# Altium schematic sheet RGB_LED_Stage_Light.SchDoc
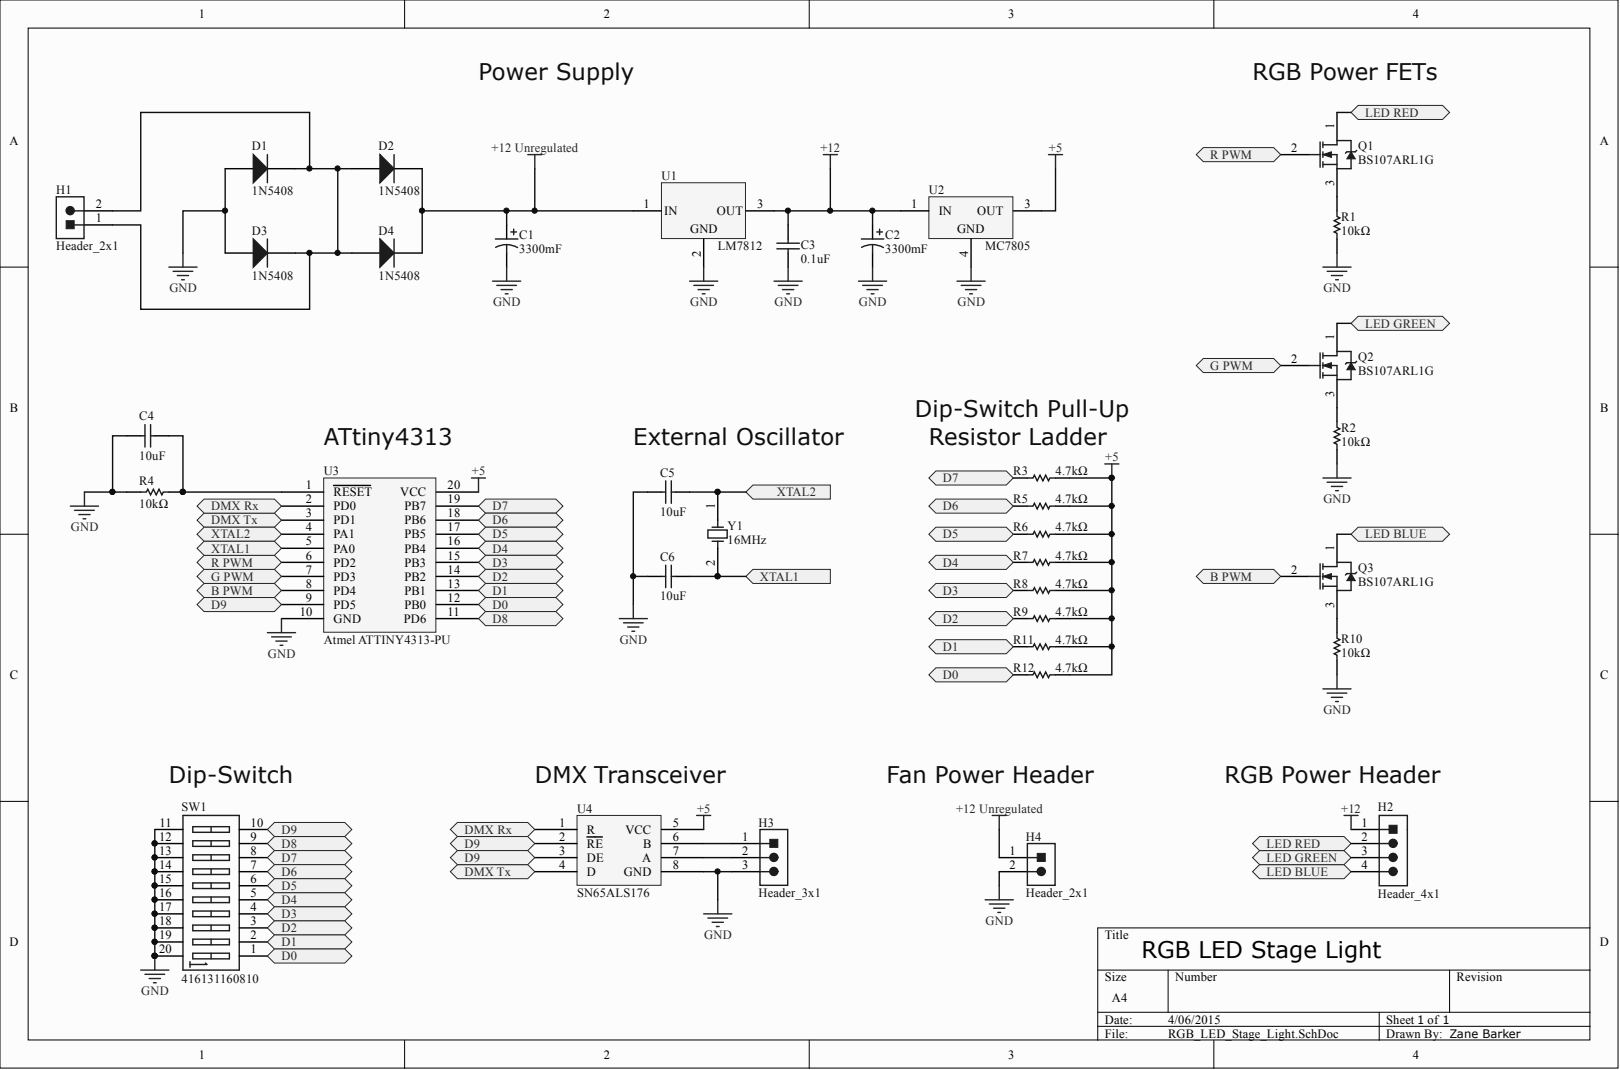
[diagram p0: RGB_LED_Stage_Light.SchDoc]

## Parts
C1  3300mF  [CAPM7343X310X130ML30T30]
C2  3300mF  [CAPM7343X310X130ML30T30]
C3  0.1uF  [PCB-3552395-2]
C4  10uF  [PCB-3552395-2]
C5  10uF  [PCB-3552395-2]
C6  10uF  [PCB-3552395-2]
D1  1N5408  [PCB-89mq2y49z58ewcnvjsi1-1]
D2  1N5408  [PCB-89mq2y49z58ewcnvjsi1-1]
D3  1N5408  [PCB-89mq2y49z58ewcnvjsi1-1]
D4  1N5408  [PCB-89mq2y49z58ewcnvjsi1-1]
H1  Header_2x1
H2  Header_4x1  [JST-S4B-XH-A(LF)(SN)]
H3  Header_3x1  [JST-B3P-VH(LF)(SN)]
H4  Header_2x1
Q1  BS107ARL1G  [ONSC-TO-92-3-29-11_AM_BL]
Q2  BS107ARL1G  [ONSC-TO-92-3-29-11_AM_BL]
Q3  BS107ARL1G  [ONSC-TO-92-3-29-11_AM_BL]
R1  10kΩ  [RESC1005X40X25NL10T10]
R2  10kΩ  [RESC1005X40X25NL10T10]
R3  4.7kΩ  [RESC1005X40X25NL10T10]
R4  10kΩ  [RESC1005X40X25NL10T10]
R5  4.7kΩ  [RESC1005X40X25NL10T10]
R6  4.7kΩ  [RESC1005X40X25NL10T10]
R7  4.7kΩ  [RESC1005X40X25NL10T10]
R8  4.7kΩ  [RESC1005X40X25NL10T10]
R9  4.7kΩ  [RESC1005X40X25NL10T10]
R10  10kΩ  [RESC1005X40X25NL10T10]
R11  4.7kΩ  [RESC1005X40X25NL10T10]
R12  4.7kΩ  [RESC1005X40X25NL10T10]
SW1  416131160810  [416131160810]
U1  LM7812
U2  MC7805  [ONSC-MC7805ACD2TG]
U3  Atmel ATTINY4313-PU  Manufacturer Part Number: ATTINY4313-PU
U4  SN65ALS176
Y1  16MHz  [FOX-277LF-16000000_V]

## Ports
B PWM, D0, D1, D2, D3, D4, D5, D6, D7, D8, D9, DMX RX, DMX TX, G PWM, LED BLUE, LED GREEN, LED RED, R PWM, XTAL1, XTAL2

## Nets
+5: R3.2 R5.2 R6.2 R7.2 R8.2 R9.2 R11.2 R12.2 U2.3 U3.20(VCC) U4.5(VCC)
+12: C2.1 C3.2 H2.1 U1.3 U2.1
+12 UNREGULATED: C1.1 D2.2 D4.2 H4.1 U1.1
GND: C1.2 C2.2 C3.1 C4.2 C5.2 C6.2 D1.1 D3.1 H3.3 H4.2 R1.1 R2.1 R4.1 R10.1 SW1.11 SW1.12 SW1.13 SW1.14 SW1.15 SW1.16 SW1.17 SW1.18 SW1.19 SW1.20 U1.2 U2.4 U3.10(GND) U4.8(GND)
B PWM: Q3.2 U3.8(PD4) ; port B PWM
D0: R12.1 SW1.1 U3.12(PB0) ; port D0
D1: R11.1 SW1.2 U3.13(PB1) ; port D1
D2: R9.1 SW1.3 U3.14(PB2) ; port D2
D3: R8.1 SW1.4 U3.15(PB3) ; port D3
D4: R7.1 SW1.5 U3.16(PB4) ; port D4
D5: R6.1 SW1.6 U3.17(PB5) ; port D5
D6: R5.1 SW1.7 U3.18(PB6) ; port D6
D7: R3.1 SW1.8 U3.19(PB7) ; port D7
D8: SW1.9 U3.11(PD6) ; port D8
D9: SW1.10 U3.9(PD5) U4.2(RE) U4.3(DE) ; port D9
G PWM: Q2.2 U3.7(PD3) ; port G PWM
LED BLUE: H2.4 Q3.1 ; port LED BLUE
LED GREEN: H2.3 Q2.1 ; port LED GREEN
LED RED: H2.2 Q1.1 ; port LED RED
R PWM: Q1.2 U3.6(PD2) ; port R PWM
XTAL1: C6.1 U3.5(PA0) Y1.2 ; port XTAL1
XTAL2: C5.1 U3.4(PA1) Y1.1 ; port XTAL2
NetC4_1: C4.1 R4.2 U3.1(RESET)
NetD1_2: D1.2 D2.1 D3.2 D4.1 H1.1 H1.2
NetH3_1: H3.1 U4.6(B)
NetH3_2: H3.2 U4.7(A)
NetQ1_3: Q1.3 R1.2
NetQ2_3: Q2.3 R2.2
NetQ3_3: Q3.3 R10.2
NetU3_2: U3.2(PD0) U4.1(R) ; port DMX RX
NetU3_3: U3.3(PD1) U4.4(D) ; port DMX TX

## Library data of the parts
C1 C2 = CMP-221418-1: CAP TANT 100UF 10V 10% 2917; Manufacturer Part Number: 293D107X9010D2TE3; Capacitance: 100000000 pF; Case Style: Molded; CiivaID: 221418; DCL: 10 uA; DELETED: Compliant; DF: 8%; ESR: 0.6 ohm; Insulation: Not Required; Lead Spacing (nom): Not Required mm; Polarity: Polar; Product Depth (mm): 4.3 mm; Product Height (mm): 2.8 mm; Product Length (mm): 7.3 mm; Rad Hardened: No; Voltage: 10VDC; Case Code: D; Ciiva URL: https://ciiva.com/part/293D107X9010D2TE3-221418; Component Kind: Standard; Construction: SMT Chip; Failure Rate: Not Required; Length W/Weld: Not Required mm; Length W/Weld (max): Not Required mm; Library Name: CommunityVault; Mounting Style: Surface Mount; Operating Temp Range: -55C to 125C; Package / Case: 7343-31; Packaging: Tape and Reel; Product Diameter (mm): Not Required mm; Seal: Not Required; Seated Plane Height: Not Required mm; Tolerance (+ or -): 10%; Wire Form: Not Required
C3-C6 = CMP-3552395-A.1: Multilayer Ceramic Capacitors MLCC - SMD/SMT 0603 0.01uF 16volts X7R 5%; Manufacturer: AVX; Manufacturer Part Number: 0603YC103JAZ2A; Product Depth: 0.81 mm; Product Height: 0.94(Max) mm; Product Length: 1.6 mm; Rad Hard: No; Voltage: 16 Vdc; Capacitance Value: 0.01 uF; Category: Capacitor Ceramic Multilayer; CiivaID: 3552395; Ciiva URL: https://ciiva.com/part/0603YC103JAZ2A-3552395; Component Kind: Standard; Construction: Flat; Dielectric: X7R; Library Name: CommunityVault; Mounting: Surface Mount; Operating Temperature: -55 to 125 °C; Package/Case: 0603; Packaging: Tape & Reel; Tolerance: 5 %
D1-D4 = 1N5408-A.1: Standard Recovery Rectifier, 2-Pin Axial_Lead, Pb-Free, Bulk Box; CustomComponentID: 89mq2y49z58ewcnvjsi1; Component Kind: Standard; Library Name: FavoritesLibrary
H1 H4 = CMP-751870-A.1: TERM BLOCK 2POS SIDE ENT 3.81MM; Manufacturer Part Number: 1776113-2; CiivaID: 751870; Clamp Material - Plating: Copper Alloy - Nickel Plated; Current: 12A; Dynamic Catalog: Buchanan Series; Featured Product: Eurostyle Terminal Block Connectors; Mating Orientation: Horizontal with Board; Number of Levels: 1; Other Names: A97976; Pitch: 0.150" (3.81mm); Positions Per Level: 2; Product Training Modules: Wire-to-Board Eurostyle Terminal Blocks; Voltage: 150V; Wire Gauge: 16-28 AWG; Wire Termination: Screw - Rising Cage Clamp; Category: Connectors, Interconnects; Ciiva URL: https://ciiva.com/part/1776113-2-751870; Color: Black; Component Kind: Standard; Contact Material - Plating: Copper Alloy - Tin Plated; Family: Terminal Blocks - Wire to Board; Housing Material: Polyamide (PA), Nylon; Library Name: CommunityVault; Mounting Type: Through Hole; Operating Temperature: -40°C ~ 110°C; Packaging: Bulk; RoHS Information: 1776113-2 Statement of Compliance; Screw Material - Plating: Copper Alloy - Nickel Plated; Series: Buchanan; Standard Package: 300
H2 = CMP-656563-1: CONN HEADER XH SIDE 4POS 2.5MM; Manufacturer Part Number: S4B-XH-A(LF)(SN); CiivaID: 656563; No of Positions: 4; Style/Orientation: Right Angle Header/Plug; Ciiva URL: https://ciiva.com/part/S4B-XH-A(LF)(SN)-656563; Component Kind: Standard; Library Name: CommunityVault; Pitch: 2.5 mm; Termination: Press-fit; Type: Shrouded Header
H3 = CMP-642977-1: CONN HEADER VH TOP 3POS 3.96MM; Manufacturer Part Number: B3P-VH(LF)(SN); CiivaID: 642977; No of Positions: 3; Style/Orientation: Straight Header/Plug; Ciiva URL: https://ciiva.com/part/B3P-VH(LF)(SN)-642977; Component Kind: Standard; Library Name: CommunityVault; Pitch: 3.96 mm; Termination: Crimp; Type: Locking Header
Q1-Q3 = CMP-3782533-1: Small Signal MOSFET, 250 A, 200 V, N-Channel, 3-Pin TO-92, Pb-Free, Tape and Reel; Channel Mode: Enhancement; Continuous Drain Current: 0.25 A; Drain-Source On-Res: 6.4 ohm; Drain-Source On-Volt: 200 V; Drain Efficiency: Not Required %; Frequency (Max): Not Required MHz; Gate-Source Voltage (Max): ?20 V; Noise Figure: Not Required dB; Number of  Elements: 1; Output Power (Max): Not Required W; Polarity: N; Power Dissipation: 0.35 W; Rad Hardened: No; CiivaID: 3782533; Ciiva URL: https://ciiva.com/part/BS107ARL1G-3782533; Component Kind: Standard; Drain Current (Max): 0.25 A; Library Name: CommunityVault; Mounting: Through Hole; Operating Temperature Classification: Military; Operating Temp Range: -55C to 150C; Package Type: TO-92; Packaging: Tape and Reel; Pin Count: 3; Power Gain: Not Required dB; Type: Small Signal
R1-R12 = CMP-2407988-1: Thick Film Resistors 10K OHM %; Manufacturer Part Number: CR0402-FX-1002GLF; Brand: Bourns; Case Height: 0.35 mm; Case Length: 1 mm; Case Width: 0.5 mm; Power Rating: 62.5 mW (1/16 W); Resistance: 10 kOhms; Temperature Coefficient: 100 PPM / C; Tolerance: 1 %; Voltage Rating: 50 V; Case Code - in: 0402; Case Code - mm: 1005; CiivaID: 2407988; Ciiva URL: https://ciiva.com/part/CR0402-FX-1002GLF-2407988; Component Kind: Standard; Dimensions: 0.5 mm W x 1 mm L x 0.35 mm H; Factory Pack Quantity: 10000; Library Name: CommunityVault; Manufacturer: Bourns; Operating Temperature Range: - 55 C to + 125 C; Package / Case: 0402 (1005 metric); Packaging: Reel; Product: Thick Film Resistors SMD; Product Category: Thick Film Resistors; RoHS: Details; Series: CR0402; Termination Style: SMD/SMT; Type: Chip Resistors
SW1 = CMP-1184337-1: WS-DISV 1.27 mm small compact SMD Dip Switch with top tape; Actuation Type: Flat; Contact Configuration: SPST; Contact Current DC Max: 25mA; Contact Resistance: 50mohm; Contact Voltage DC Nom: 24V; External Depth: 5.6mm; External Length / Height: 2mm; External Width: 13.74mm; Insulation Resistance: 100Mohm; No. of Switch Positions: 10; Pitch Spacing: 1.27mm; SVHC: No SVHC (18-Jun-2012); Actuator Style: Flat; CiivaID: 1184337; Ciiva URL: https://ciiva.com/part/416131160810-1184337; Colour: Black; Component Kind: Standard; Contact Material: Copper Alloy; Contact Plating: Gold; Library Name: CommunityVault; Material: PPS / PA46; Operating Temperature Max: 85°C; Operating Temperature Min: -40°C; Switch Mounting: SMD; Switch Type: DIP; Terminal Plating: Gold
U1 = CMP-1492924-A.2: IC REG LDO 12V 1A TO220-3; Manufacturer Part Number: LM7812ACT; Brand: Fairchild Semiconductor; Input Voltage MAX: 27 V; Input Voltage MIN: 14.8 V; Line Regulation: 120 mV; Load Regulation: 100 mV; Number of Outputs: 1; Output Type: Fixed; Polarity: Positive; PSRR / Ripple Rejection (Typ): 60 dB; Unit Weight: 0.042823 oz; CiivaID: 1492924; Ciiva URL: https://ciiva.com/part/lm7812act-1492924; Component Kind: Standard; Factory Pack Quantity: 50; Library Name: CommunityVault; Manufacturer: Fairchild Semiconductor; Maximum Operating Temperature: + 125 C; Minimum Operating Temperature: 0 C; Mounting Style: Through Hole; Output Current: 1 A; Output Voltage: 12 V; Package / Case: TO-220-3; Packaging: Tube; Product Category: Linear Voltage Regulators; PSRR / Ripple Rejection - Typ: 60 dB; RoHS: Details; Series: LM7812
U2 = CMP-1211210-1: IC REG LDO 5V 1A D2PAK; Manufacturer Part Number: MC7805ACD2TG; Accuracy %: 2%; Dropout Voltage Vdo: 2V; Input Voltage Max: 20VDC; No. of Outputs: 1; No. of Pins: 3; Primary Input Voltage: 10V; Supply Voltage Min: 7VDC; SVHC: No SVHC (19-Dec-2012); Voltage Regulator Case Style: TO-263; Voltage Regulator Type: Positive Voltage; CiivaID: 1211210; Ciiva URL: https://ciiva.com/part/MC7805ACD2TG-1211210; Component Kind: Standard; Library Name: CommunityVault; Operating Temperature Max: 125°C; Operating Temperature Min: 0°C; Operating Temperature Range: 0°C to +125°C; Output Current: 1A; Output Current Max: 1A; Output Voltage: 5V; Output Voltage Fixed: 5V; Output Voltage Max: 5V; Package / Case: D2-Pak; Supply Voltage Max: 20VDC; Termination Type: SMD
U3 = CMP-2386845-A.1: MCU 8-bit ATtiny AVR RISC 4KB Flash 2.5V/3.3V/5V 20-Pin PDIP W; Data Bus Width: 8Bit; Maximum Frequency: 20MHz; Maximum Number of CAN Channels: 0; Maximum Number of I2C Channels: 1; Maximum Number of SPI Channels: 2; Program Memory Size: 256 B, 4 kB; Pulse Width Modulation: 2 x 16 bit, 2 x 8 bit; PWM Resolution: 16 bit, 8 bit; RAM Size: 256 B; Timers: 1 x 16 bit, 1 x 8 bit; Typical Operating Supply Voltage: 1.8  5.5 V; CiivaID: 2386845; Ciiva URL: https://ciiva.com/part/attiny4313-pu-2386845; Component Kind: Standard; Device Core: AVR; Dimensions: 25.984 x 7.112 x 4.953mm; Family Name: ATtiny; Height: 4.953mm; Instruction Set Architecture: RISC; Length: 25.984mm; Library Name: CommunityVault; Maximum Number of Ethernet Channels: 0; Maximum Number of LIN Channels: 0; Maximum Number of PCI Channels: 0; Maximum Number of UART Channels: 1; Maximum Number of USART Channels: 1; Maximum Operating Temperature: +85 C; Minimum Operating Temperature: -40 C; Mounting Type: Through Hole; Number of PWM Units: 2; Number of Timers: 2; Package Type: PDIP; Pin Count: 20; Program Memory Type: Flash; PWM Channels: 2; Timer Resolution: 16 bit, 8 bit; USB Channels: 0; Width: 7.112mm
U4 = CMP-973201-A.1: Single Transmitter/Receiver RS-422/RS-485 8-Pin SOIC N Rail; Manufacturer Part Number: DS36C278TM/NOPB; CiivaID: 973201; Data Transmission Topology: Multipoint; Driving Mode: 3-State; ESD Protection: Yes; Interface Standards: EIA-485; Maximum Single Supply Voltage: 5.25V; Maximum Supply Current: 0.5mA; Minimum Single Supply Voltage: 4.75V; Number of Drivers per Line: 64; Number of Receiver Enables: 1; Pin_Count: 8; Product Height: 1.45mm; Product Length: 4.9mm; Product Width: 3.9mm; Receiver Communication Type: RS-485; Receiver Signal Type: Differential; Screening Level: Industrial; Typical Single Supply Voltage: 5V; Ciiva URL: https://ciiva.com/part/ds36c278tm-nopb-973201; Component Kind: Standard; Family: DS36C278; Library Name: CommunityVault; Maximum Operating Temperature: 85C; Minimum Operating Temperature: -40C; Mounting: Surface Mount; Number of Receivers: 1; Number of Receivers per Line: 64; Number of Transceivers: 1; Number of Transmitter Enables: 1; Number of Transmitters: 1; Packaging: Rail; Power Supply Type: Single; Product Type: Line Transceiver; Supplier_Package: SOIC N; Transmitter Communication Type: RS-485; Transmitter Signal Type: Differential
Y1 = CMP-779993-1: CRYSTAL 16.0MHZ 20PF THRU; Manufacturer Part Number: FOXSLF/160-20; Brand: Fox; Case Height: 3.6 mm; Case Length: 11.35 mm; Case Width: 4.65 mm; CiivaID: 779993; ESR: 40 Ohms; Frequency Stability: 50 PPM; Ciiva URL: https://ciiva.com/part/foxslf-160-20-779993; Component Kind: Standard; Dimensions: 4.65 mm W x 11.35 mm L x 3.6 mm H; Factory Pack Quantity: 100; Frequency: 16 MHz; Library Name: CommunityVault; Load Capacitance: 20 pF; Manufacturer: Fox; Mounting Style: Through Hole; Operating Temperature Range: - 20 C to + 70 C; Package / Case: HC-49/US; Packaging: Bulk; Product Category: Crystals; RoHS: Details; Series: HC49S; Termination Style: Radial; Tolerance: 50 PPM; Type: Resistance Weld Thru-Hole Crystal
